# Altium schematic sheet Sin wave.SchDoc
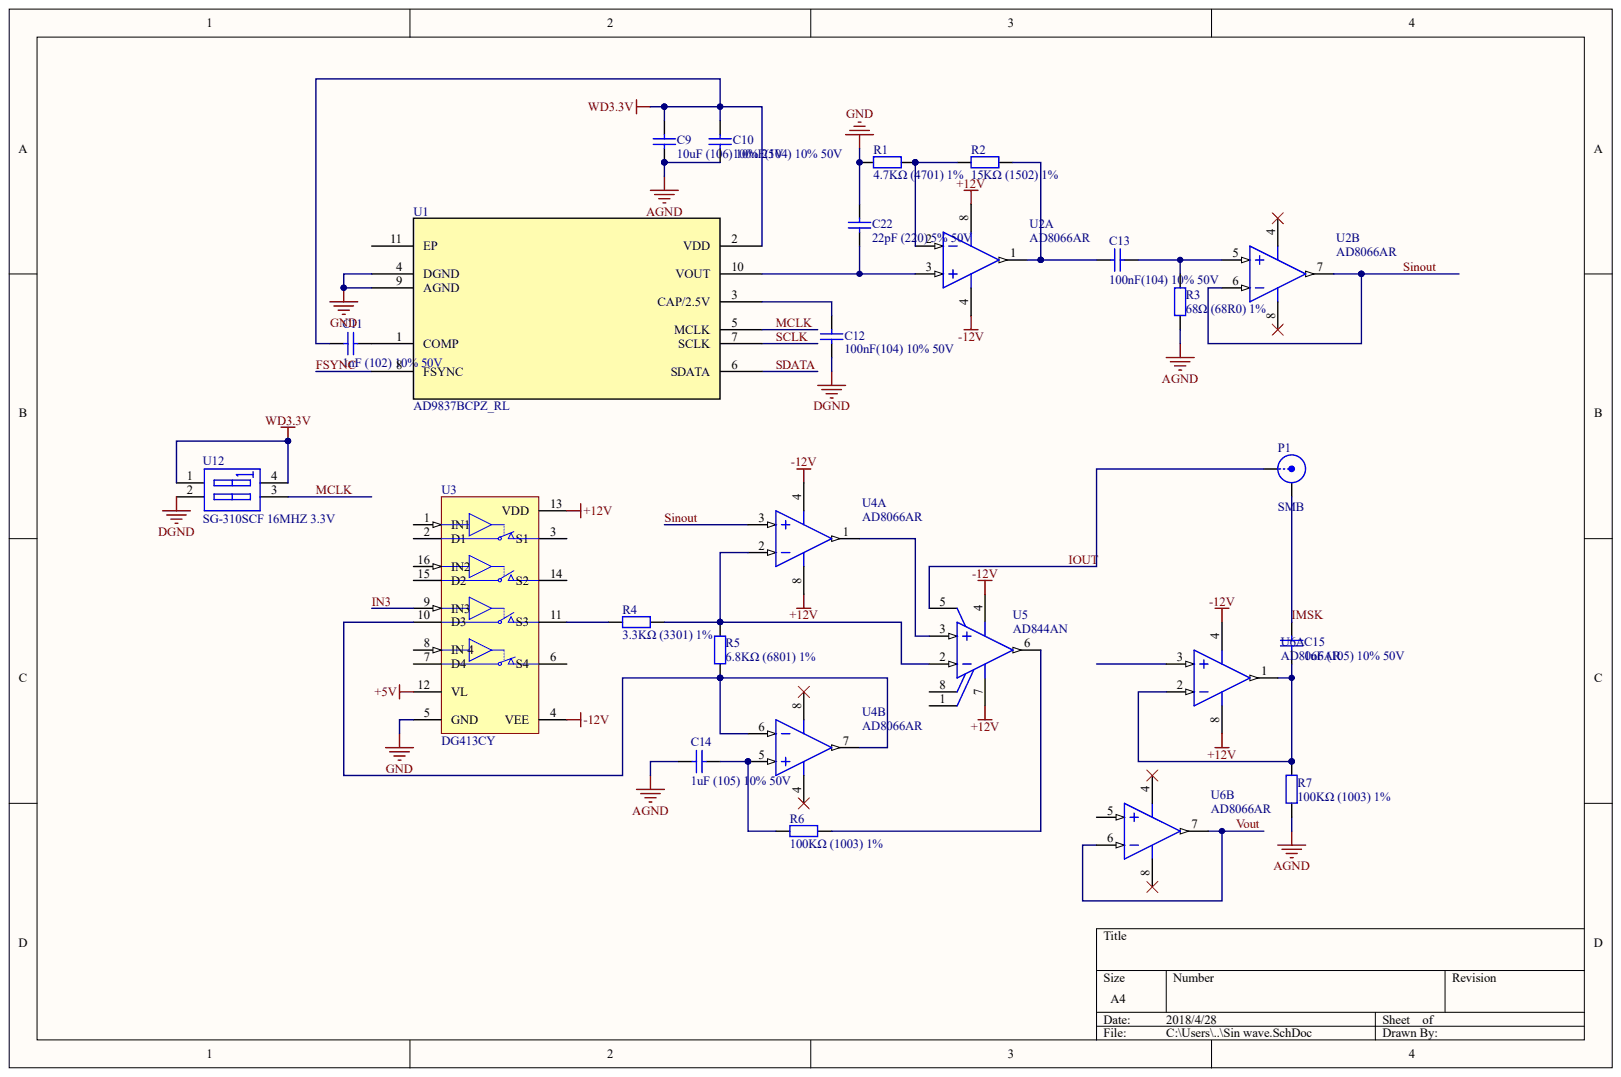
[diagram p0: Sin wave.SchDoc]

## Parts
C9  10uF (106) 10% 25V
C10  100nF(104) 10% 50V
C11  1nF (102) 10% 50V
C12  100nF(104) 10% 50V
C13  100nF(104) 10% 50V
C14  1uF (105) 10% 50V
C15  1uF (105) 10% 50V
C22  22pF (220) 5% 50V
P1  SMB
R1  4.7KΩ (4701) ±1%
R2  15KΩ (1502) ±1%
R3  68Ω (68R0) ±1%  (value not drawn)
R4  3.3KΩ (3301) ±1%
R5  6.8KΩ (6801) ±1%
R6  100KΩ (1003) ±1%
R7  100KΩ (1003) ±1%
U1  AD9837BCPZ_RL
U2  AD8066AR  (parts A,B)
U3  DG413CY
U4  AD8066AR  (parts A,B)
U5  AD844AN
U6  AD8066AR  (parts A,B)
U12  SG-310SCF 16MHZ 3.3V

## Nets
+5V: U3.12(VL)
+12V: U2.8 U3.13(VDD) U4.8 U5.7 U6.8
-12V: U2.4 U3.4(VEE) U4.4 U5.4 U6.4
AGND: C9.1 C10.1 C14.1 R3.1 R7.1
DGND: C12.1 U12.2
GND: C22.2 R1.1 U1.4(DGND) U1.9(AGND) U3.5(GND)
WD3.3V: C9.2 C10.2 C11.1 U1.2(VDD) U12.1 U12.4
FSYNC: U1.8(FSYNC)
IMSK: C15.2 P1.2
IN3: U3.9(IN3)
IOUT: P1.1 U5.5
MCLK: U1.5(MCLK) U12.3
SCLK: U1.7(SCLK)
SDATA: U1.6(SDATA)
SINOUT: U2.6 U2.7 U4.3
VOUT: U6.6 U6.7
NetC11_2: C11.2 U1.1(COMP)
NetC12_2: C12.2 U1.3(CAP/2.5V)
NetC13_1: C13.1 R2.2 U2.1
NetC13_2: C13.2 R3.2 U2.5
NetC14_2: C14.2 R6.1 U4.5
NetC15_1: C15.1 R7.2 U6.1 U6.2
NetC22_1: C22.1 U1.10(VOUT) U2.3
NetR1_2: R1.2 R2.1 U2.2
NetR4_1: R4.1 U3.11(S3)
NetR4_2: R4.2 R5.2 U4.2 U5.2
NetR5_1: R5.1 U3.10(D3) U4.6 U4.7
NetR6_2: R6.2 U5.6
NetU4_1: U4.1 U5.3

Not connected: U1 11(EP); U3 1(IN1) 2(D1) 3(S1) 6(S4) 7(D4) 8(IN_4) 14(S2) 15(D2) 16(IN2); U5 1 8; U6 3 5

## Library data of the parts
U2 U4 U6 = (no symbol name): Generic Part Number: AD8066; Manufacturer: Analog Devices; Amplifiers Per Package: 2; Input Bias Current: 2pA; Input Offset Voltage: 400uV; Iq/Amp: 7.4mA; Slew Rate: 180V/us; Small Signal Bandwidth: 145MHz; V Span Max: 24V; V Span Min: 5V; DatasheetVersion: Rev. J; PackageVersion: 060506-A; PackageReference: R-8; PackageDescription: 8-Pin Standard Small Outline Package, Body 3.9 x 4.9 mm, Pitch 1.27 mm; Supplier 1: Digi-Key; Supplier Part Number 1: AD8066AR-ND; Mounting Technology: Surface Mount; Code_JEDEC: MS-012-AA; PartNumber: AD8066AR; ComponentLink1Description: Manufacturer URL; ComponentLink1URL: http://www.analog.com/en/index.html; ComponentLink2Description: Datasheet; ComponentLink2URL: http://www.analog.com/static/imported-files/data_sheets/AD8065_8066.pdf; ComponentLink3Description: Package Specification; ComponentLink3URL: http://www.analog.com/static/imported-files/packages/PKG_PDF/SOIC_Narrow%20(R)/R_8.pdf; ComponentLink4Description: Reference Circuit; ComponentLink4URL: http://www.analog.com/en/all-operational-amplifiers-op-amps/operational-amplifiers-op-amps/ad8066/products/product.html#product-recommendations
U5 = (no symbol name): Generic Part Number: AD844; Manufacturer: Analog Devices; Amplifiers Per Package: 1; Input Bias Current: 150nA; Input Offset Voltage: 50uV; Iq/Amp: 6.5mA; Packing: Tube; Slew Rate: 2KV/us; Small Signal Bandwidth: 60MHz; V Span Max: 36V; V Span Min: 9V; DatasheetVersion: Rev. F; PackageVersion: 070606-A; PackageReference: N-8; PackageDescription: 8-Pin Plastic Dual In-Line Package, Row spacing 7.62 mm, Pitch 2.54 mm; Supplier 1: Digi-Key; Supplier Part Number 1: AD844AN-ND; Mounting Technology: Through Hole; Code_JEDEC: MS-001; PartNumber: AD844AN; ComponentLink1Description: Manufacturer URL; ComponentLink1URL: http://www.analog.com/en/index.html; ComponentLink2Description: Datasheet; ComponentLink2URL: http://www.analog.com/static/imported-files/data_sheets/AD844.pdf; ComponentLink3Description: Package Specification; ComponentLink3URL: http:/www.analog.com/static/imported-files/packages/PKG_PDF/PDIP(N)/N_8.pdf
C9-C15 C22 P1 R1-R7 U1 U3 U12 = (no symbol name)
  C9: ComponentLink1Description: 供应商链接; ComponentLink1URL: http://www.szlcsc.com/product/details_16532.html; Package: 0805; Supplier: LC; SuppliersPartNumber: C15850
  C10 C12 C13: ComponentLink1Description: 供应商链接; ComponentLink1URL: http://www.szlcsc.com/product/details_50686.html; Package: 0805; Supplier: LC; SuppliersPartNumber: C49678
  C11: ComponentLink1Description: 供应商链接; ComponentLink1URL: http://www.szlcsc.com/product/details_52223.html; Package: 0805; Supplier: LC; SuppliersPartNumber: C51210
  C14 C15: ComponentLink1Description: 供应商链接; ComponentLink1URL: http://www.szlcsc.com/product/details_29074.html; Package: 0805; Supplier: LC; SuppliersPartNumber: C28323
  C22: ComponentLink1Description: 供应商链接; ComponentLink1URL: http://www.szlcsc.com/product/details_2156.html; Package: 0805; Supplier: LC; SuppliersPartNumber: C1804
  P1: LatestRevisionDate: 17-Jul-2002; LatestRevisionNote: Re-released for DXP Platform.
  R1: ComponentLink1Description: 供应商链接; ComponentLink1URL: http://www.szlcsc.com/product/details_18361.html; Package: 0805; Supplier: LC; SuppliersPartNumber: C17673
  R2: ComponentLink1Description: 供应商链接; ComponentLink1URL: http://www.szlcsc.com/product/details_18163.html; Package: 0805; Supplier: LC; SuppliersPartNumber: C17475
  R3: ComponentLink1Description: 供应商链接; ComponentLink1URL: http://www.szlcsc.com/product/details_18490.html; Package: 0805; Supplier: LC; SuppliersPartNumber: C17802
  R4: ComponentLink1Description: 供应商链接; ComponentLink1URL: http://www.szlcsc.com/product/details_26753.html; Package: 0805; Supplier: LC; SuppliersPartNumber: C26010
  R5: ComponentLink1Description: 供应商链接; ComponentLink1URL: http://www.szlcsc.com/product/details_18460.html; Package: 0805; Supplier: LC; SuppliersPartNumber: C17772
  R6 R7: ComponentLink1Description: 供应商链接; ComponentLink1URL: http://www.szlcsc.com/product/details_18095.html; Package: 0805; Supplier: LC; SuppliersPartNumber: C17407
  U1: Value: Value; Type: Type; RefDes: RefDes
  U3: Published: 16-Jan-2001; LatestRevisionDate: 13-Apr-2006; LatestRevisionNote: IPC-7351 Footprint Added.; DatasheetVersion: Aug-1995; PackageReference: NSO16; PackageDescription: 16-Pin Small Outline Integrated Circuit 1.27 mm Pitch; Code_JEDEC: MS-012-AC; Code_IPC: SOIC127P600-16; ComponentLink1Description: Manufacturer Link; ComponentLink1URL: http://www.maxim-ic.com/; ComponentLink2Description: Datasheet; ComponentLink2URL: http://pdfserv.maxim-ic.com/en/ds/DG411-DG413.pdf
  U12: Published: 24-Mar-1999; LatestRevisionDate: 17-Jul-2002; LatestRevisionNote: Re-released for DXP Platform.; PackageReference: DIP-4
  P1 U3 U12: Publisher: Altium Limited
